# Altium schematic sheet MeteoStation_ETH.SchDoc
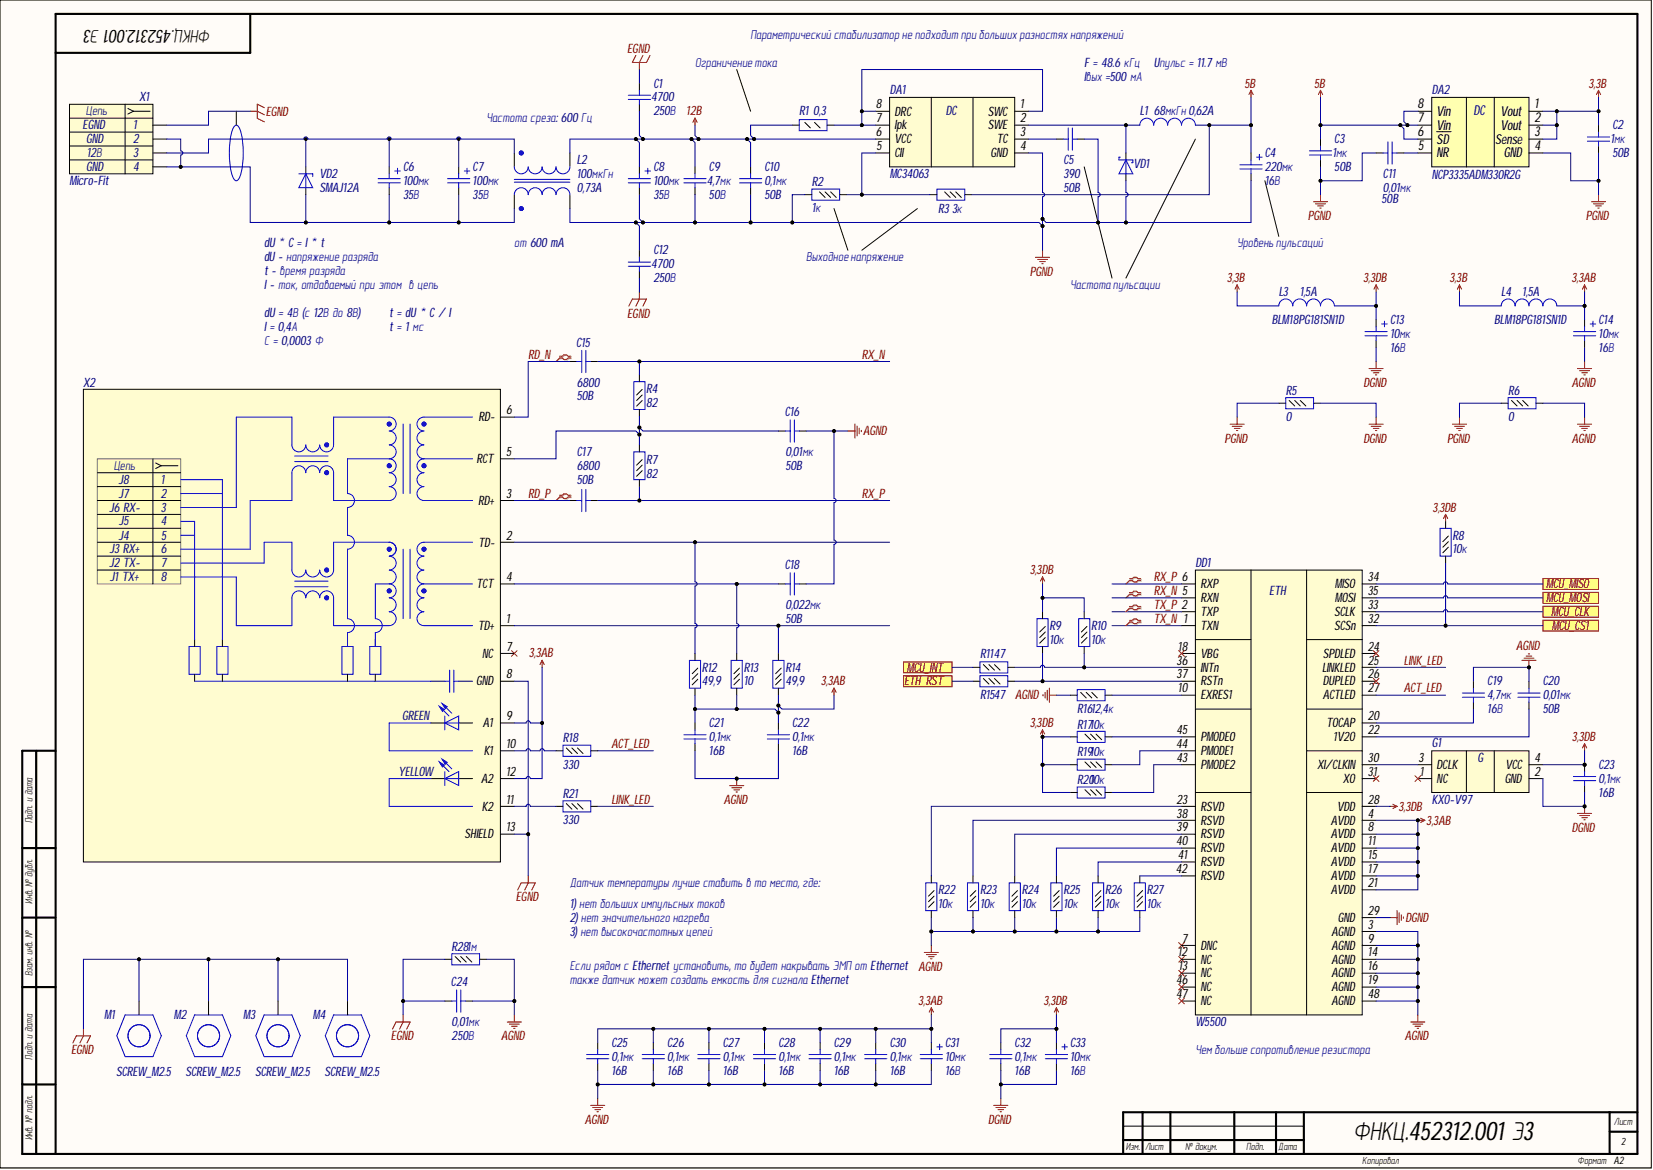
[diagram p0: MeteoStation_ETH.SchDoc]

## Parts
C1  value 4700  Voltage: 250В  [C0805]  (hidden comment: Capacitor)
C2  value 1мк  Voltage: 50B  [C0603]  (hidden comment: Capacitor)
C3  value 1мк  Voltage: 50B  [C0603]  (hidden comment: Capacitor)
C4  value 220мк  Voltage: 16В  [K50-35(6.3X11)_MIRROR]  (hidden comment: Capacitor_pol)
C5  value 390  [C0603]  (hidden comment: Capacitor)
C6  value 100мк  Voltage: 35В  [K50-35(6.3X11)]  (hidden comment: Capacitor_pol)
C7  value 100мк  Voltage: 35В  [K50-35(6.3X11)]  (hidden comment: Capacitor_pol)
C8  value 100мк  Voltage: 35В  [K50-35(6.3X11)_MIRROR]  (hidden comment: Capacitor_pol)
C9  value 4,7мк  Voltage: 50В  [C1206]  (hidden comment: Capacitor)
C10  value 0,1мк  Voltage: 50B  [C0603]  (hidden comment: Capacitor)
C11  value 0,01мк  Voltage: 50B  [C0603]  (hidden comment: Capacitor)
C12  value 4700  Voltage: 250В  [C0805]  (hidden comment: Capacitor)
C13  value 10мк  Voltage: 16В  [TANTAL CASE A]  (hidden comment: Capacitor_pol)
C14  value 10мк  Voltage: 16В  [TANTAL CASE A]  (hidden comment: Capacitor_pol)
C15  value 6800  Voltage: 50B  [C0603]  (hidden comment: Capacitor)
C16  value 0,01мк  Voltage: 50B  [C0402]  (hidden comment: Capacitor)
C17  value 6800  Voltage: 50B  [C0603]  (hidden comment: Capacitor)
C18  value 0,022мк  Voltage: 50B  [C0402]  (hidden comment: Capacitor)
C19  value 4,7мк  Voltage: 16В  [C0805]  (hidden comment: Capacitor)
C20  value 0,01мк  Voltage: 50B  [C0402]  (hidden comment: Capacitor)
C21  value 0,1мк  Voltage: 16B  [C0402]  (hidden comment: Capacitor)
C22  value 0,1мк  Voltage: 16B  [C0402]  (hidden comment: Capacitor)
C23  value 0,1мк  Voltage: 16B  [C0402]  (hidden comment: Capacitor)
C24  value 0,01мк  Voltage: 250В  [C0805]  (hidden comment: Capacitor)
C25  value 0,1мк  Voltage: 16B  [C0402]  (hidden comment: Capacitor)
C26  value 0,1мк  Voltage: 16B  [C0402]  (hidden comment: Capacitor)
C27  value 0,1мк  Voltage: 16B  [C0402]  (hidden comment: Capacitor)
C28  value 0,1мк  Voltage: 16B  [C0402]  (hidden comment: Capacitor)
C29  value 0,1мк  Voltage: 16B  [C0402]  (hidden comment: Capacitor)
C30  value 0,1мк  Voltage: 16B  [C0402]  (hidden comment: Capacitor)
C31  value 10мк  Voltage: 16В  [TANTAL CASE A]  (hidden comment: Capacitor_pol)
C32  value 0,1мк  Voltage: 16B  [C0402]  (hidden comment: Capacitor)
C33  value 10мк  Voltage: 16В  [TANTAL CASE A]  (hidden comment: Capacitor_pol)
DA1  MC34063  [SO8]
DA2  NCP3335ADM330R2G  [MICRO8]
DD1  Part Number: W5500  [LQFP48]
G1  KXO  Part Number: KXO-V97  [QSMD7x5.2x1.8]
L1  value 68мкГн  Rated Current: 0,62A  [SDR0604]  (hidden comment: Inductor)
L2  value 100мкГн  Rated Current: 0,73A  [SRF0703]  (hidden comment: Dual-Winding Inductor)
L3  Part Number: BLM18PG181SN1D  Rated Current: 1,5A  [L0603]  (hidden comment: Inductor)
L4  Part Number: BLM18PG181SN1D  Rated Current: 1,5A  [L0603]  (hidden comment: Inductor)
M1  SCREW_M2.5  [HOLE2.5]
M2  SCREW_M2.5  [HOLE2.5]
M3  SCREW_M2.5  [HOLE2.5]
M4  SCREW_M2.5  [HOLE2.5]
R1  value 0,3  [R0805]  (hidden comment: Resistor_0,125Вт)
R2  value 1к  [R0603]  (hidden comment: Resistor_0,062Вт)
R3  value 3к  [R0603]  (hidden comment: Resistor_0,062Вт)
R4  value 82  [R0402]  (hidden comment: Resistor_0,062Вт)
R5  value 0  [R0603]  (hidden comment: Resistor_0,062Вт)
R6  value 0  [R0603]  (hidden comment: Resistor_0,062Вт)
R7  value 82  [R0402]  (hidden comment: Resistor_0,062Вт)
R8  value 10к  [R0402]  (hidden comment: Resistor_0,062Вт)
R9  value 10к  [R0402]  (hidden comment: Resistor_0,062Вт)
R10  value 10к  [R0402]  (hidden comment: Resistor_0,062Вт)
R11  value 47  [R0402]  (hidden comment: Resistor_0,062Вт)
R12  value 49,9  [R0402]  (hidden comment: Resistor_0,062Вт)
R13  value 10  [R0402]  (hidden comment: Resistor_0,062Вт)
R14  value 49,9  [R0402]  (hidden comment: Resistor_0,062Вт)
R15  value 47  [R0402]  (hidden comment: Resistor_0,062Вт)
R16  value 12,4к  [R0603]  (hidden comment: Resistor_0,062Вт)
R17  value 10к  [R0402]  (hidden comment: Resistor_0,062Вт)
R18  value 330  [R0402]  (hidden comment: Resistor_0,062Вт)
R19  value 10к  [R0402]  (hidden comment: Resistor_0,062Вт)
R20  value 10к  [R0402]  (hidden comment: Resistor_0,062Вт)
R21  value 330  [R0402]  (hidden comment: Resistor_0,062Вт)
R22  value 10к  [R0402]  (hidden comment: Resistor_0,062Вт)
R23  value 10к  [R0402]  (hidden comment: Resistor_0,062Вт)
R24  value 10к  [R0402]  (hidden comment: Resistor_0,062Вт)
R25  value 10к  [R0402]  (hidden comment: Resistor_0,062Вт)
R26  value 10к  [R0402]  (hidden comment: Resistor_0,062Вт)
R27  value 10к  [R0402]  (hidden comment: Resistor_0,062Вт)
R28  value 1м  [R0603]  (hidden comment: Resistor_0,062Вт)
VD1  DiodeSchottky  [SOD-323]  (value not drawn)
VD2  DiodeZener  [SMA]  (value not drawn)
X1  Micro-Fit  Contact_1: EGND  Contact_2: GND  Contact_3: 12В  [43045-0400]
X2  HR911105A  [RJ45_HANRUN]  (value not drawn)

## Ports
ETH_RST, MCU_CLK, MCU_CS1, MCU_INT, MCU_MISO, MCU_MOSI

## Nets
3,3AB: C14.1 C21.2 C22.2 C25.2 C26.2 C27.2 C28.2 C29.2 C30.2 C31.1 DD1.4(AVDD) DD1.8(AVDD) DD1.11(AVDD) DD1.15(AVDD) DD1.17(AVDD) DD1.21(AVDD) L4.2 R12.1 R13.1 R14.1 X2.9(A1) X2.12(A2)
3,3B: C2.2 DA2.1(Vout) DA2.2(Vout) DA2.3(Sense) L3.1 L4.1
3,3DB: C13.1 C23.2 C32.2 C33.1 DD1.28(VDD) G1.4(VCC) L3.2 R8.2 R9.2 R10.2 R17.2 R19.2 R20.2
5B: C3.2 C4.1 DA2.6(SD) DA2.7(Vin) DA2.8(Vin) L1.2 R3.1
12B: C1.1 C8.1 C9.2 C10.2 DA1.6(VCC) L2.4 R1.1
AGND: C14.2 C16.2 C18.2 C19.2 C20.2 C21.1 C22.1 C24.2 C25.1 C26.1 C27.1 C28.1 C29.1 C30.1 C31.2 DD1.3(AGND) DD1.9(AGND) DD1.14(AGND) DD1.16(AGND) DD1.19(AGND) DD1.48(AGND) R6.2 R16.1 R22.1 R23.1 R24.1 R25.1 R26.1 R27.1 R28.2
DGND: C13.2 C23.1 C32.1 C33.2 DD1.29(GND) G1.2(GND) R5.2
EGND: C1.2 C12.1 C24.1 M1.1 M2.1 M3.1 M4.1 R28.1 X1.1 X2.8(GND) X2.13(SHIELD)
PGND: C2.1 C3.1 C4.2 C5.2 C8.2 C9.1 C10.1 C11.2 C12.2 DA1.4(GND) DA2.4(GND) L2.3 R2.2 R5.1 R6.1 VD1.2
ACT_LED: DD1.27(ACTLED) R18.2
ETH_RST: R15.1 ; port ETH_RST
LINK_LED: DD1.25(LINKLED) R21.2
MCU_CLK: DD1.33(SCLK) ; port MCU_CLK
MCU_CS1: DD1.32(SCSn) R8.1 ; port MCU_CS1
MCU_INT: R11.1 ; port MCU_INT
MCU_MISO: DD1.34(MISO) ; port MCU_MISO
MCU_MOSI: DD1.35(MOSI) ; port MCU_MOSI
RD_N: C15.1 X2.6(RD-)
RD_P: C17.1 X2.3(RD+)
RX_N: C15.2 DD1.5(RXN) R4.2
RX_P: C17.2 DD1.6(RXP) R7.1
TX_N: DD1.1(TXN)
TX_P: DD1.2(TXP)
NetC5_1: C5.1 DA1.3(TC)
NetC6_1: C6.1 C7.1 L2.2 VD2.1 X1.3
NetC6_2: C6.2 C7.2 L2.1 VD2.2 X1.2 X1.4
NetC11_1: C11.1 DA2.5(NR)
NetC16_1: C16.1 R4.1 R7.2 X2.5(RCT)
NetC18_1: C18.1 R13.2 X2.4(TCT)
NetC19_1: C19.1 DD1.20(TOCAP)
NetC20_1: C20.1 DD1.22(1V2O)
NetDA1_1: DA1.1(SWC) DA1.7(Ipk) DA1.8(DRC) R1.2
NetDA1_2: DA1.2(SWE) L1.1 VD1.1
NetDA1_5: DA1.5 R2.1 R3.2
NetDD1_10: DD1.10(EXRES1) R16.2
NetDD1_23: DD1.23(RSVD) R22.2
NetDD1_30: DD1.30(XI/CLKIN) G1.3(DCLK)
NetDD1_36: DD1.36(INTn) R10.1 R11.2
NetDD1_37: DD1.37(RSTn) R9.1 R15.2
NetDD1_38: DD1.38(RSVD) R23.2
NetDD1_39: DD1.39(RSVD) R24.2
NetDD1_40: DD1.40(RSVD) R25.2
NetDD1_41: DD1.41(RSVD) R26.2
NetDD1_42: DD1.42(RSVD) R27.2
NetDD1_43: DD1.43(PMODE2) R20.1
NetDD1_44: DD1.44(PMODE1) R19.1
NetDD1_45: DD1.45(PMODE0) R17.1
NetR12_2: R12.2 X2.2
NetR14_2: R14.2 X2.1
NetR18_1: R18.1 X2.10(K1)
NetR21_1: R21.1 X2.11(K2)

Not connected: DD1 7(DNC) 12(NC) 13(NC) 18(VBG) 24(SPDLED) 26(DUPLED) 31(XO) 46(NC) 47(NC); G1 1(NC); X2 7(NC)

## Library data of the parts
C1 C12 = cap170: Конденсатор; Manufacture: Murata; Part Name: Ceramic; Part Number: GRM21AR72E472KW; Database Table Name: Capacitors$; TKE: X7R; Component Kind: Standard; id: cap170; Library Name: MOP44.DbLib
C2 C3 = cap133: Конденсатор; Manufacture: Murata; Part Name: Ceramic; Part Number: GRM188R71C105KA12D**; Database Table Name: Capacitors$; TKE: X7R; Component Kind: Standard; id: cap133; Library Name: MOP44.DbLib
C4 = cap230: Конденсатор полярный; Manufacture: Jamicon; Part Name: Electrolit; Part Number: TKR221M1CE11; Database Table Name: Capacitors$; tga: 0,20%; Component Kind: Standard; id: cap230; Library Name: MOP44.DbLib
C5 = cap99: Конденсатор; Manufacture: Murata; Part Name: Ceramic; Part Number: GRM1885C1H391J; Database Table Name: Capacitors$; TKE: NP0; Voltage: 50B; Component Kind: Standard; id: cap99; Library Name: MOP44.DbLib
C6 C7 = cap226: Конденсатор полярный; Manufacture: Jamicon; Part Name: Electrolit; Part Number: TKR101M1VE11; Database Table Name: Capacitors$; tga: 0,20%; Component Kind: Standard; id: cap226; Library Name: MOP44.DbLib
C8 = cap225: Конденсатор полярный; Manufacture: Jamicon; Part Name: Electrolit; Part Number: TKR101M1VE11; Database Table Name: Capacitors$; tga: 0,20%; Component Kind: Standard; id: cap225; Library Name: MOP44.DbLib
C9 = cap188: Конденсатор; Manufacture: Murata; Part Name: Ceramic; Part Number: GRM31CR71H475KA12L; Database Table Name: Capacitors$; TKE: X7R; Component Kind: Standard; id: cap188; Library Name: MOP44.DbLib
C10 = cap131: Конденсатор; Manufacture: Murata; Part Name: Ceramic; Part Number: GRM188R71H104KA93D; Database Table Name: Capacitors$; TKE: X7R; Component Kind: Standard; id: cap131; Library Name: MOP44.DbLib
C11 = cap124: Конденсатор; Manufacture: Murata; Part Name: Ceramic; Part Number: GRM188R71H103K; Database Table Name: Capacitors$; TKE: X7R; Component Kind: Standard; id: cap124; Library Name: MOP44.DbLib
C13 C14 C31 C33 = cap199: Конденсатор полярный; Manufacture: Vishay; Part Name: Tantal; Part Number: 293D106X9016A2TE3; Database Table Name: Capacitors$; Component Kind: Standard; id: cap199; Library Name: MOP44.DbLib
C15 C17 = cap122: Конденсатор; Manufacture: Murata; Part Name: Ceramic; Part Number: GRM188R71H682K; Database Table Name: Capacitors$; TKE: X7R; Component Kind: Standard; id: cap122; Library Name: MOP44.DbLib
C16 C20 = cap42: Конденсатор; Manufacture: Murata; Part Name: Ceramic; Part Number: GRM155R71H103K; Database Table Name: Capacitors$; TKE: X7R; Component Kind: Standard; id: cap42; Library Name: MOP44.DbLib
C18 = cap43: Конденсатор; Manufacture: Murata; Part Name: Ceramic; Part Number: GRM155R71H223K; Database Table Name: Capacitors$; TKE: X7R; Component Kind: Standard; id: cap43; Library Name: MOP44.DbLib
C19 = cap234: Конденсатор; Manufacture: Murata; Part Name: Ceramic; Part Number: GRM21BR71C475KA73L; Database Table Name: Capacitors$; TKE: X7R; Component Kind: Standard; id: cap234; Library Name: MOP44.DbLib
C21-C23 C25-C30 C32 = cap49: Конденсатор; Manufacture: Murata; Part Name: Ceramic; Part Number: GRM155R71C104KA88D; Database Table Name: Capacitors$; TKE: X7R; Component Kind: Standard; id: cap49; Library Name: MOP44.DbLib
C24 = cap135: Конденсатор; Manufacture: Murata; Part Name: Ceramic; Part Number: GRM21BR72E103K; Database Table Name: Capacitors$; TKE: X7R; Component Kind: Standard; id: cap135; Library Name: MOP44.DbLib
DA1 = pwr21: 1.5 A, Step-Up/Down/  Inverting Switching  Regulators; Manufacturer: ON Semiconductor; Part Number: MC34063ADR2G; Current: 1,5A; Database Table Name: PowerTransducer$; Input Voltage: 3-40B; Output Voltage: Adj; Component Kind: Standard; id: pwr21; Library Name: MOP44.DbLib; Part Name: 1.5 A, Step-Up/Down/ Inverting Switching Regulators; Type: Impulse
DA2 = pwr16: Линейный стабилизатор напряжения, фиксированный выход; Manufacturer: ON Semiconductor; Part Name: Low-Dropout Linear Regulator 2 outputs; Current: 800mA; Database Table Name: PowerTransducer$; Input Voltage: 16B; Output Voltage: 3,3B; Component Kind: Standard; id: pwr16; Library Name: MOP44.DbLib; Part Number: NCP3335ADM330R2G; Type: Linear
DD1 = interf19: Ethernet - SPI; Manufacture: WIZnet; Current Consumption: 132mA; Database Table Name: Interface$; Power Supply: 2.97-3.63V; Type Supply: Single Polar; Component Kind: Standard; id: interf19; Library Name: MOP44.DbLib; Part Name: ETHERNET - SPI
G1 = crystal9: Кварц. генер. 32.768 МГц (HCMOS/TTL); Manufacture: GEYER; Database Table Name: Crystal$; Frequence: 25MHz; Component Kind: Standard; id: crystal9; Library Name: MOP44.DbLib
L1 = ind52: EMI Suppression Filter; Manufacture: Bourns; Part Name: SMD Power Inductors; Part Number: SDR0604- 680KL; Database Table Name: Inductor$; Resistance: 0,52Ohm; Saturation Current: 0,84A; Component Kind: Standard; id: ind52; Library Name: MOP44.DbLib
L2 = ind24: Синфазный дроссель; Manufacture: Bourns; Part Name: Dual-Winding Shielded Power Inductors; Part Number: SRF0703-101M; Database Table Name: Inductor$; Resistance: 0,715Ohm; Saturation Current: 0,79A; Component Kind: Standard; id: ind24; Library Name: MOP44.DbLib
L3 L4 = ind0: EMI Suppression Filter; Part Name: EMI Suppression Filters; Database Table Name: Inductor$; Resistance: 0,09Ohm; Component Kind: Standard; id: ind0; Library Name: MOP44.DbLib
M1-M4 = connect53: Part Name: Винт М2.5 с заземлением; Database Table Name: Connector$; Component Kind: Standard; id: connect53; Library Name: MOP44.DbLib
R1 = res982: Резистор; Manufacture: Yageo; Part Number: RC0805FR-0749R9L; Accuracy: 0,01; Database Table Name: Resistor$; Component Kind: Standard; id: res982; Library Name: MOP44.DbLib; Part Name: Resistor; Power: 0,125Вт
R2 = res459: Резистор; Manufacture: Yageo; Part Number: RC0603FR-071KL; Accuracy: 0,01; Database Table Name: Resistor$; Component Kind: Standard; id: res459; Library Name: MOP44.DbLib; Part Name: Resistor; Power: 0,062Вт
R3 = res470: Резистор; Manufacture: Yageo; Part Number: RC0603FR-073KL; Accuracy: 0,01; Database Table Name: Resistor$; Component Kind: Standard; id: res470; Library Name: MOP44.DbLib; Part Name: Resistor; Power: 0,062Вт
R4 R7 = res240: Резистор; Manufacture: Yageo; Part Number: RC0402FR-0782RL; Accuracy: 0,01; Database Table Name: Resistor$; Component Kind: Standard; id: res240; Library Name: MOP44.DbLib; Part Name: Resistor; Power: 0,062Вт
R5 R6 = res386: Резистор; Manufacture: Yageo; Part Number: RC0603FR-070RL; Accuracy: 0,01; Database Table Name: Resistor$; Component Kind: Standard; id: res386; Library Name: MOP44.DbLib; Part Name: Resistor; Power: 0,062Вт
R8-R10 R17 R19 R20 R22-R27 = res290: Резистор; Manufacture: Yageo; Part Number: RC0402FR-0710KL; Accuracy: 0,01; Database Table Name: Resistor$; Component Kind: Standard; id: res290; Library Name: MOP44.DbLib; Part Name: Resistor; Power: 0,062Вт
R11 R15 = res234: Резистор; Manufacture: Yageo; Part Number: RC0402FR-0747RL; Accuracy: 0,01; Database Table Name: Resistor$; Component Kind: Standard; id: res234; Library Name: MOP44.DbLib; Part Name: Resistor; Power: 0,062Вт
R12 R14 = res979: Резистор; Manufacture: Yageo; Part Number: RC0402FR-0749R9L; Accuracy: 0,01; Database Table Name: Resistor$; Component Kind: Standard; id: res979; Library Name: MOP44.DbLib; Part Name: Resistor; Power: 0,062Вт
R13 = res218: Резистор; Manufacture: Yageo; Part Number: RC0402FR-0710RL; Accuracy: 0,01; Database Table Name: Resistor$; Component Kind: Standard; id: res218; Library Name: MOP44.DbLib; Part Name: Resistor; Power: 0,062Вт
R16 = res973: Резистор; Manufacture: Yageo; Part Number: RC0603FR-0712K4L; Accuracy: 0,01; Database Table Name: Resistor$; Component Kind: Standard; id: res973; Library Name: MOP44.DbLib; Part Name: Resistor; Power: 0,062Вт
R18 R21 = res254: Резистор; Manufacture: Yageo; Part Number: RC0402FR-07330RL; Accuracy: 0,01; Database Table Name: Resistor$; Component Kind: Standard; id: res254; Library Name: MOP44.DbLib; Part Name: Resistor; Power: 0,062Вт
R28 = res531: Резистор; Manufacture: Yageo; Part Number: RC0603FR-071ML; Accuracy: 0,01; Database Table Name: Resistor$; Component Kind: Standard; id: res531; Library Name: MOP44.DbLib; Part Name: Resistor; Power: 0,062Вт
VD1 = diode19: Диод Шоттки; Manufacture: NXP Semiconductor; Part Number: PMEG4010BEA.115; Capacitance: 50pF; Database Table Name: Diode$; Forward Current: 10mA; Forward Voltage: 0,64B; Max Reverse Voltage: 40B; Number of Channels: 1; Component Kind: Standard; id: diode19; Library Name: MOP44.DbLib; Part Name: Diode Schottky
VD2 = SMAJ12A: Cтабилитрон (лавинный выпрямительный диод) односторонний; Manufacture: ST Microelectronics; Part Name: TVS diode Unidirectional; Breakdown Voltage: 14B; Clamping voltage: 19,9B; Database Table Name: TVSdiode$; Dynamic resistance: 0,259Ohm; id: tvs128; Leakage Current: 0,2uA; Number of diodes: 1; Peak Pulse Power: 400W; Stand-off Voltage: 12B; Component Kind: Standard; Library Name: MOP44.DbLib; Part Number: SMAJ12A
X1 = connect51: Разъем - 4 конт. розетка; Manufacture: Molex; Part Name: Micro-Fit 3.0 Right-Angle Header, 3.00mm Pitch; Database Table Name: Connector$; Component Kind: Standard; Contact_4: GND; id: connect51; Library Name: MOP44.DbLib; Part Number: 43045-0400
X2 = connect52: Разъем - 6 конт. вилка; Manufacture: HanRun; Part Name: RJ45 connector with EMI & LEDs; Database Table Name: Connector$; Component Kind: Standard; id: connect52; Library Name: MOP44.DbLib; Part Number: HR911105A
